# Altium schematic sheet TVR_Rev2_Power.SchDoc(TVR_Rev2_Power)
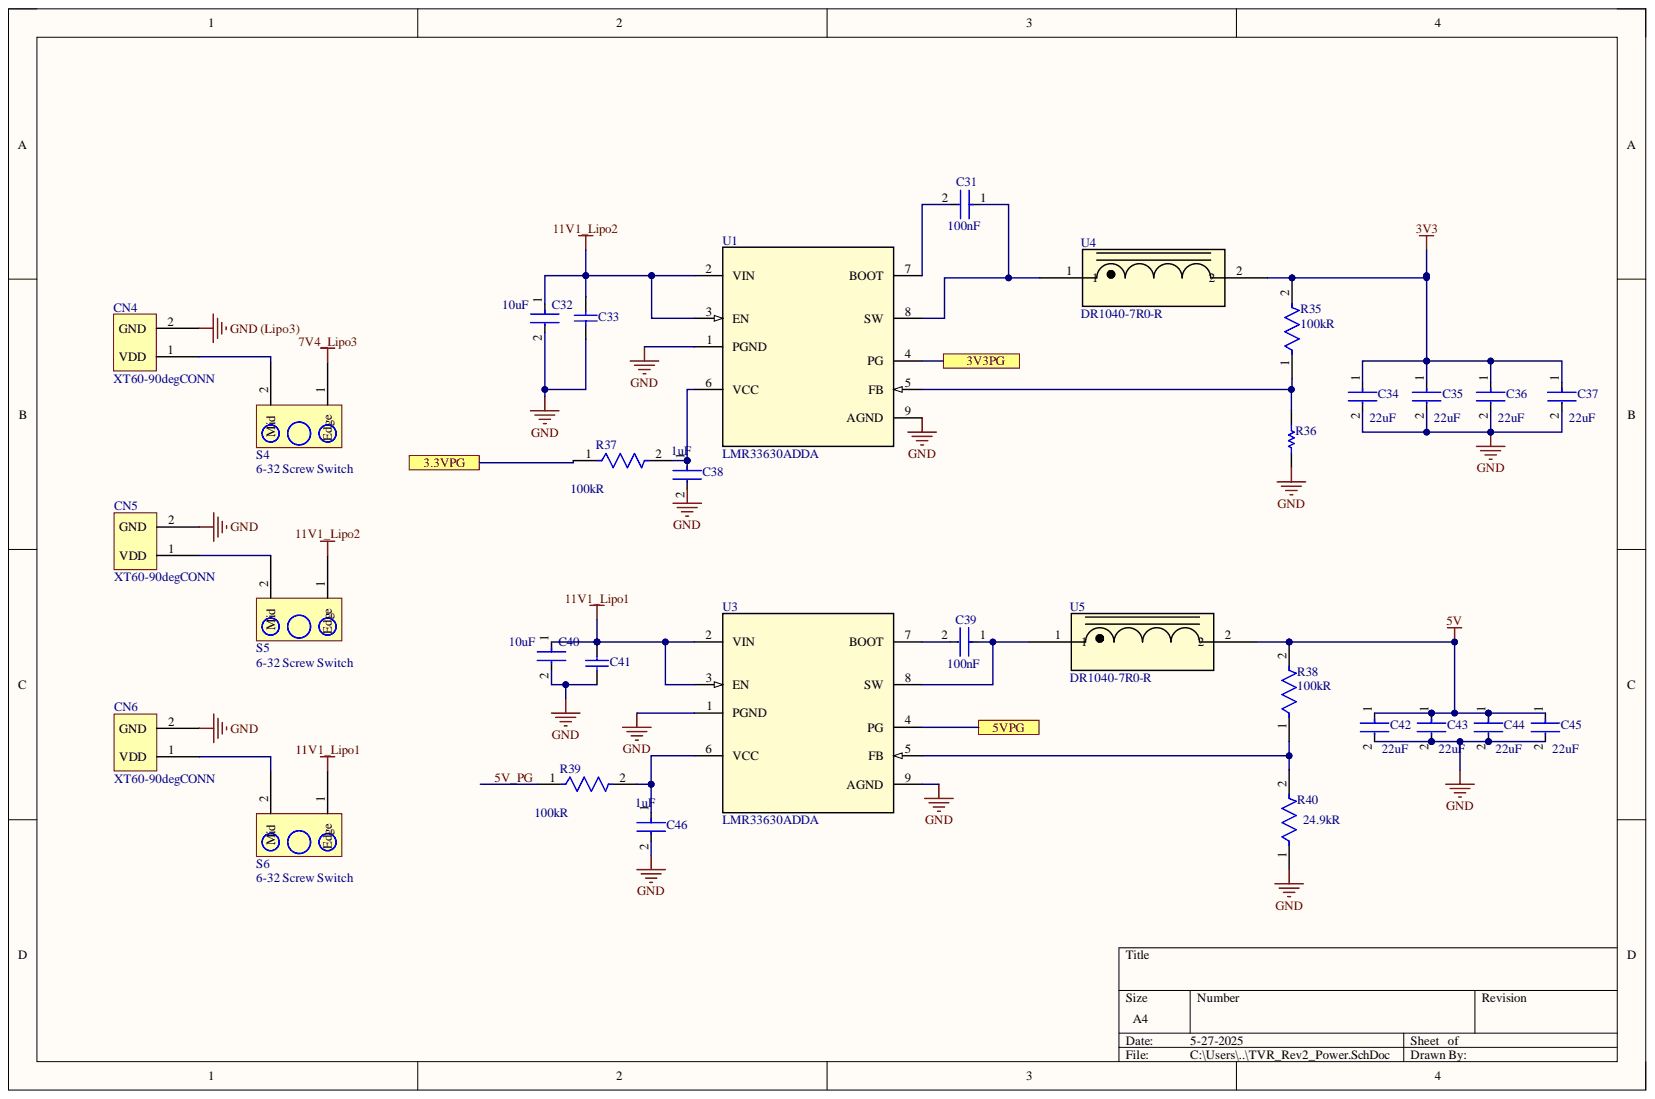
[diagram p0: TVR_Rev2_Power.SchDoc]

## Parts
C31  Capacitance: 100nF  [FP-C2012-125-0_45-MFG]  (hidden comment: C2012X7T2E104K125AE)
C32  Capacitance: 10uF  [FP-GRM21B-0_15-IPC_C]  (hidden comment: GRM21BR61E106KA73L)
C33  08051C224KAT2A  [CAPC2013X94X50ML20T25]  (value not drawn)
C34  Capacitance: 22uF  [FP-CL21-IPC_A]  (hidden comment: CL21A226KOQNNNG)
C35  Capacitance: 22uF  [FP-CL21-IPC_A]  (hidden comment: CL21A226KOQNNNG)
C36  Capacitance: 22uF  [FP-CL21-IPC_A]  (hidden comment: CL21A226KOQNNNG)
C37  Capacitance: 22uF  [FP-CL21-IPC_A]  (hidden comment: CL21A226KOQNNNG)
C38  Capacitance: 1uF  [FP-C2012-125-IPC_B]  (hidden comment: C2012X7S2A105K125AE)
C39  Capacitance: 100nF  [FP-C2012-125-0_45-MFG]  (hidden comment: C2012X7T2E104K125AE)
C40  Capacitance: 10uF  [FP-GRM21B-0_15-IPC_C]  (hidden comment: GRM21BR61E106KA73L)
C41  08051C224KAT2A  [CAPC2013X94X50ML20T25]  (value not drawn)
C42  Capacitance: 22uF  [FP-CL21-IPC_A]  (hidden comment: CL21A226KOQNNNG)
C43  Capacitance: 22uF  [FP-CL21-IPC_A]  (hidden comment: CL21A226KOQNNNG)
C44  Capacitance: 22uF  [FP-CL21-IPC_A]  (hidden comment: CL21A226KOQNNNG)
C45  Capacitance: 22uF  [FP-CL21-IPC_A]  (hidden comment: CL21A226KOQNNNG)
C46  Capacitance: 1uF  [FP-C2012-125-IPC_B]  (hidden comment: C2012X7S2A105K125AE)
CN4  XT60-90degCONN  [XT60-90CONN]
CN5  XT60-90degCONN  [XT60-90CONN]
CN6  XT60-90degCONN  [XT60-90CONN]
R35  Resistance: 100kR  [FP-RC0805-0_6-IPC_C]  (hidden comment: RC0805FR-07100KL)
R36  RC0805FR-0743K2L  [RESC2013X60X35NL10T20]  (value not drawn)
R37  Resistance: 100kR  [FP-RC0805-0_6-IPC_C]  (hidden comment: RC0805FR-07100KL)
R38  Resistance: 100kR  [FP-RC0805-0_6-IPC_C]  (hidden comment: RC0805FR-07100KL)
R39  Resistance: 100kR  [FP-RC0805-0_6-IPC_C]  (hidden comment: RC0805FR-07100KL)
R40  Resistance: 24.9kR  [FP-RMCF1210-MFG]  (hidden comment: RMCF1210FT24K9)
S4  6-32 Screw Switch  [6-32 Screw Switch]
S5  6-32 Screw Switch  [6-32 Screw Switch]
S6  6-32 Screw Switch  [6-32 Screw Switch]
U1  LMR33630ADDA  [DDA0008J]
U3  LMR33630ADDA  [DDA0008J]
U4  DR1040-7R0-R  [DR1040-7R0-R_EAT]
U5  DR1040-7R0-R  [DR1040-7R0-R_EAT]

## Ports
3.3VPG, 3V3PG, 5VPG

## Nets
3V3: C34.1 C35.1 C36.1 C37.1 R35.2 U4.2
5V: C42.1 C43.1 C44.1 C45.1 R38.2 U5.2
7V4_Lipo3: S4.1
11V1_Lipo1: C40.1 C41.2 S6.1 U3.2(VIN) U3.3(EN)
11V1_Lipo2: C32.1 C33.2 S5.1 U1.2(VIN) U1.3(EN)
GND: C32.2 C33.1 C34.2 C35.2 C36.2 C37.2 C38.2 C40.2 C41.1 C42.2 C43.2 C44.2 C45.2 C46.2 CN5.2 CN6.2 R36.1 R40.1 U1.1(PGND) U1.9(AGND) U3.1(PGND) U3.9(AGND)
GND (Lipo3): CN4.2
3.3VPG: R37.1 ; port 3.3VPG
3V3PG: U1.4(PG) ; port 3V3PG
5V_PG: R39.1
5VPG: U3.4(PG) ; port 5VPG
NetC31_1: C31.1 U1.8(SW) U4.1
NetC31_2: C31.2 U1.7(BOOT)
NetC38_1: C38.1 R37.2 U1.6(VCC)
NetC39_1: C39.1 U3.8(SW) U5.1
NetC39_2: C39.2 U3.7(BOOT)
NetC46_1: C46.1 R39.2 U3.6(VCC)
NetCN4_1: CN4.1 S4.2
NetCN5_1: CN5.1 S5.2
NetCN6_1: CN6.1 S6.2
NetR35_1: R35.1 R36.2 U1.5(FB)
NetR38_1: R38.1 R40.2 U3.5(FB)

## Library data of the parts
C31 C39 = CMP-08246-004341-1: CAP CER 0.1UF 250V X7T 0805; Tolerance: 10%; Case Code (Imperial): 0805; Case Code (Metric): 2012; Case/Package: 0805; Component Kind: Standard; Depth: 1.25mm; Dielectric: X7T; Features: Soft Termination; Height: 1.25mm; Length: 2mm; Library Name: Altium Content Vault; Max Operating Temperature: 125°C; Min Operating Temperature: -55°C; Mount: Surface Mount; Package Quantity: 1; Packaging: Tape and Reel; REACH SVHC: No SVHC; Resistor Type: Ceramic; RoHS Compliant: Yes; Series: C; Termination: SMD/SMT; Thickness: 0.049inch; Voltage Rating: 250V; Voltage Rating (DC): 250V; Weight: 0.000194oz; Width: 0.05inch
C32 C40 = CMP-2007-03541-2: Chip Multilayer Ceramic Capacitors for General Purpose, 0805, 10uF, X5R, 15%, 10%, 25V; Case Code (Imperial): 0805; Case Code (Metric): 2012; Case/Package: 0805; Component Kind: Standard; Depth: 1.25mm; Dielectric: X5R; Height: 1.25mm; Lead Free: Lead Free; Length: 2mm; Library Name: Altium Content Vault; Material: Ceramic; Max Operating Temperature: 85°C; Min Operating Temperature: -55°C; Mount: Surface Mount; Package Quantity: 1; Packaging: Tape and Reel; Radiation Hardening: No; Termination: SMD/SMT; Thickness: 0.053inch; Tolerance: 10%; Voltage: 25V; Voltage Rating: 25V; Voltage Rating (DC): 25V; Width: 0.049inch
C33 C41 = CMP-2007-04189-1: Manufacturer: AVX Interconnect / Elco; Component Kind: Standard; Library Name: Altium Content Vault; Part Number: 08051C224KAT2A
C34-C37 C42-C45 = CMP-13271-000211-1: CL21 Series 0805 22uF 16V ±10% Tolerance X5R Multilayer Ceramic Chip Capacitor; Case/Package: 0805; Component Kind: Standard; Lead Free: Lead Free; Length: 0.079inch; Library Name: Altium Content Vault; Max Operating Temperature: 85°C; Min Operating Temperature: -55°C; Mount: Surface Mount; Package Quantity: 3000; Packaging: Tape and Reel; Thickness: 0.055inch; Tolerance: 10%; Voltage Rating: 16V; Width: 0.049inch
C38 C46 = CMP-08246-004333-1: CAP CER 1UF 100V X7S 0805; Tolerance: 10%; Case Code (Imperial): 0805; Case Code (Metric): 2012; Case/Package: 0805; Component Kind: Standard; Depth: 1.25mm; Dielectric: X7S; Features: Soft Termination; Height: 1.25mm; Lead Free: Lead Free; Length: 2mm; Library Name: Altium Content Vault; Max Operating Temperature: 125°C; Min Operating Temperature: -55°C; Mount: Surface Mount; Package Quantity: 1; Packaging: Tape and Reel; Resistor Type: Ceramic; RoHS Compliant: Yes; Series: C; Termination: SMD/SMT; Thickness: 0.049inch; Voltage Rating: 100V; Voltage Rating (DC): 100V; Width: 0.05inch
R35 R37-R39 = CMP-2100-03668-5: RES 100K OHM 1% 1/8W 0805; Max Power Dissipation: 125mW; Temperature Coefficient: 100ppm/°C; Voltage Rating: 150V; Case Code (Imperial): 0805; Case Code (Metric): 2012; Case/Package: 0805; Component Kind: Standard; Construction: Rectangular; Depth: 1.25mm; Features: Moisture Resistant; Height: 0.024inch; Lead Free: Lead Free; Lead Pitch: 0.4mm; Length: 0.079inch; Library Name: Altium Content Vault; Max Operating Temperature: 155°C; Military Standard: Not; Min Operating Temperature: -55°C; Number of Pins: 2; Number of Terminations: 2; Package Quantity: 1; Packaging: Tape and Reel; Power Rating: 125mW; Resistance Tolerance: 1%; Resistor Type: Thick Film; RoHS Compliant: Yes; Series: RC; Size Code: 0805; Technology: THICK FILM; Termination: SMD/SMT; Tolerance: 1%; Voltage Rating (DC): 150V; Width: 0.049inch
R36 = CMP-2001-01147-1: Manufacturer: Yageo; Component Kind: Standard; Library Name: Altium Content Vault; Part Number: RC0805FR-0743K2L
R40 = CMP-26527-004872-1: 24.9kΩ ±1% 0.5W 1210 Thick Film Chip Resistor AEC-Q200 compliant; Max Power Dissipation: 333mW; Temperature Coefficient: 100ppm/°C; Case Code (Imperial): 1210; Case Code (Metric): 3225; Case/Package: 1210; Component Kind: Standard; Contact Plating: Tin; Features: Automotive AEC-Q200; Height: 0.028inch; Length: 0.126inch; Library Name: Altium Content Vault; Max Operating Temperature: 155°C; Min Operating Temperature: -55°C; Mount: Surface Mount; Number of Terminations: 2; Package Quantity: 1; Packaging: Tape and Reel; Radiation Hardening: No; Resistor Type: Thick Film; RoHS Compliant: Yes; Series: RMCF; Tolerance: 1%; Width: 0.098inch
U1 U3 = LMR33630ADDA: No Description Available; Mfr_Name: Texas Instruments; Component Kind: Standard; RefDes: RefDes; TYPE: TYPE; Library Name: TVR.SchLib
U4 U5 = DR1040-7R0-R: No Description Available; Mfr_Name: Eaton / Coiltronics; Component Kind: Standard; RefDes: RefDes; Type: Type; Library Name: TVR.SchLib
CN4-CN6 = XT60-90degCONN: Component Kind: Standard; Library Name: Schlib2.SchLib
S4-S6 = 6-32 Screw Switch: Component Kind: Standard; Library Name: Schlib2.SchLib
